# Altium schematic sheet Power_Tree.SchDoc
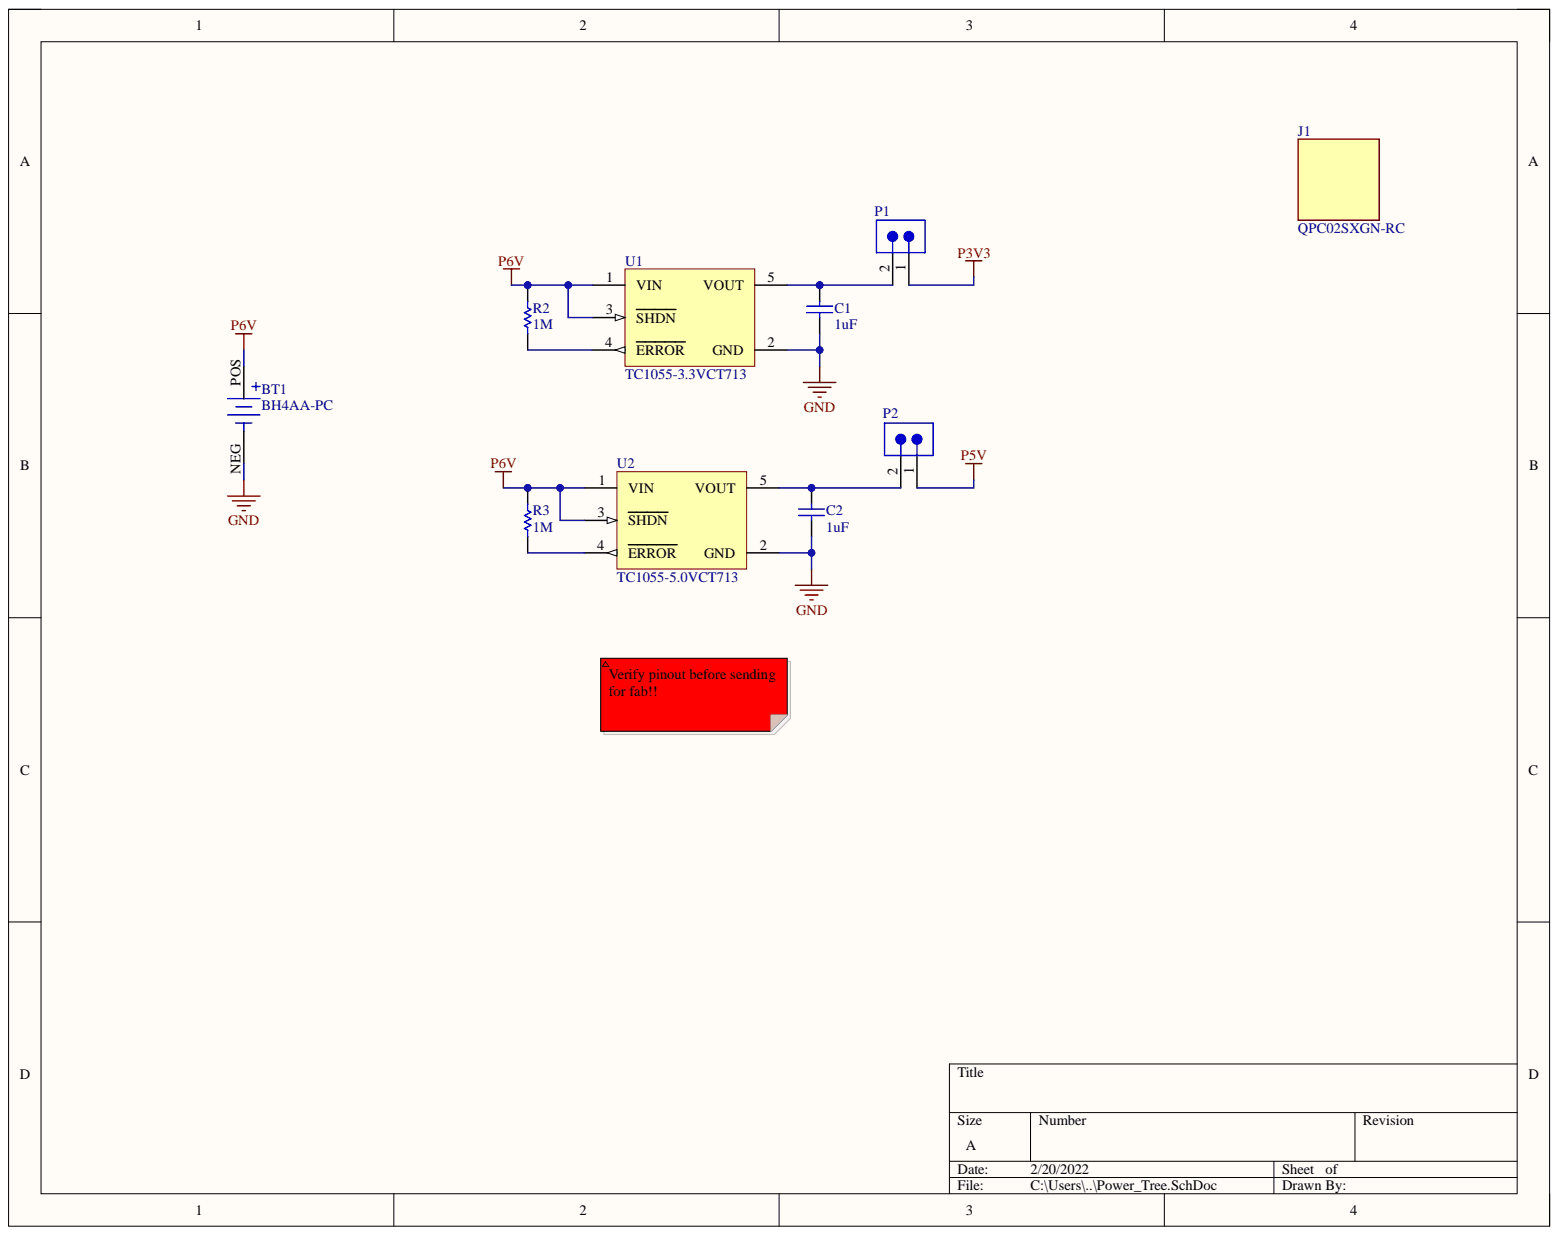
[diagram p0: Power_Tree.SchDoc]

## Parts
BT1  BH4AA-PC  [BAT_BH4AA-PC]
C1  value 1uF  [FOOTPRINT-1206-GEN]  (hidden comment: 1206 1uF)
C2  value 1uF  [FOOTPRINT-1206-GEN]  (hidden comment: 1206 1uF)
J1  QPC02SXGN-RC  [FP-QPC02SXGN-RC-MFG]
P1  TSW-102-26-F-S  [SMTC-TSW-102-26-XX-S]  (value not drawn)
P2  TSW-102-26-F-S  [SMTC-TSW-102-26-XX-S]  (value not drawn)
R2  1M  [RESC3116X65X50NL20T20]
R3  1M  [RESC3116X65X50NL20T20]
U1  TC1055-3.3VCT713  [SOT-23-CT5_L]
U2  TC1055-5.0VCT713  [SOT-23-CT5_N]

## Nets
GND: BT1.NEG C1.1 C2.1 U1.2(GND) U2.2(GND)
P3V3: P1.1
P5V: P2.1
P6V: BT1.POS R2.2 R3.2 U1.1(VIN) U1.3(SHDN) U2.1(VIN) U2.3(SHDN)
NetC1_2: C1.2 P1.2 U1.5(VOUT)
NetC2_2: C2.2 P2.2 U2.5(VOUT)
NetR2_1: R2.1 U1.4(ERROR)
NetR3_1: R3.1 U2.4(ERROR)

## Library data of the parts
BT1 = BH4AA-PC: Battery Holder _Open_ AA 4 Cell PC Pin; MF: MPD; MP: BH4AAPC; Availability: Unavailable; Component Kind: Standard; Library Name: Schlib1.SchLib; Package: None; Price: None; SnapEDA_Link: https://www.snapeda.com/parts/BH4AAPC/MPD/view-part/112528/?ref=snap
C1 C2 = CMP-001-00085-2: CAP CER 1UF 50V X7R 1206 ±10% 50V Ceramic Capacitor X7R 1206 (3216 Metric); Part Number: 1276-6781-1-ND; Component Kind: Standard; Design Item ID: Capacitor-Generic-1206; LatestRevisionNote: Re-released for DXP Platform.; PackageDescription: Radial Cap, Thru-Hole; 2 Leads; 0.3 in Pin Spacing; PackageReference: RAD-0.3; Published: 8-Jun-2000; Simulation: CAP; Source: Generic.SchLib; Type: Capacitor; Library Name: University of California Riverside - FSAE; Publisher: Altium Limited
J1 = CMP-25666-000001-1: CONN JUMPER SHORTING .100" GOLD; Manufacturer: Sullins; Conn Orientation: Straight; Current Rating: 3A; Number Of Contacts: 2; Pitch: 2.54mm; Automotive: No; Category: Conn; Component Kind: Standard; ComponentLink2Description: Datasheet; ComponentLink2URL: https://s3.amazonaws.com/catalogspreads-pdf/PAGE128-129%20.100%20JUMPER.pdf; Contact Material: Gold; Device Class L1: Connectors; Device Class L2: unset; Device Class L3: unset; Digikey Description: CONN JUMPER SHORTING .100" GOLD; Footprint Url: https://drawings-pdf.s3.amazonaws.com/11510.pdf; Height: 6.17mm; Lead Free: Yes; Library Name: Altium Content Vault; Manufacturer Part Number: QPC02SXGN-RC; Octopart: https://octopart.com/qpc02sxgn-rc-sullins-19878795; Package: 0; RoHS: TRUE; Temperature: -40°C to +85°C; Temperature Range High: +85°C; Temperature Range Low: -40°C
P1 P2 = CMP-002-00072-1: Headers & Wire Housings Classic PCB Header Strips, 0.100 pitch; Contact Post Length: 3.2mm; Gender: Male; Max Current Rating: 7.6A; Max Voltage Rating (AC): 550V; Number of Contacts: 2; Pitch: 2.54mm; Voltage Rating: 500V; Component Kind: Standard; Contact Gender: Male; Contact Material: Bronze; Contact Mating Length: 5.84mm; Contact Plating: Gold; Contact Type: -; Depth: 2.54mm; Flammability Rating: UL94 V-0; Housing Color: Black; Housing Material: Polyester; Length: 5.08mm; Max Operating Temperature: 125°C; Min Operating Temperature: -55°C; Number of Positions: 2; Number of Rows: 1; Orientation: Vertical; Package Quantity: 1; Packaging: Bulk; Radiation Hardening: No; REACH SVHC: No SVHC; RoHS Compliant: Yes; Series: TSW; Termination: Through Hole; Weight: 0.001257oz; Library Name: University of California Riverside - FSAE
R2 R3 = CMP-2003-04887-1: CRCW12061M00FKEAHP  1M 1206; Manufacturer: Vishay Dale; Component Kind: Standard; Library Name: Altium Content Vault; Part Number: CRCW12061M00FKEAHP
U1 = CMP-0136-00572-1: 50 mA, 100 mA and 150 mA CMOS LDOs with Shutdown and Active-Low Error Output, 5-Pin SOT-23, Extended Temperature, Tape and Reel; Code_IPC: SOT23-5; Component Kind: Standard; DatasheetVersion: revD, Feb-2007; Library Name: Altium Content Vault; PackageDescription: 5-Lead Plastic Small Outline Transistor (CT) [SOT-23]; PackageReference: SOT-23-CT5; PackageVersion: revBB, Aug-2009
U2 = CMP-0136-00550-1: 50 mA, 100 mA and 150 mA CMOS LDOs with Shutdown and Active-Low Error Output, 5-Pin SOT-23, Extended Temperature, Tape and Reel; Code_IPC: SOT23-5; Component Kind: Standard; DatasheetVersion: revD, Feb-2007; Library Name: Altium Content Vault; PackageDescription: 5-Lead Plastic Small Outline Transistor (CT) [SOT-23]; PackageReference: SOT-23-CT5; PackageVersion: revBB, Aug-2009
